# Altium schematic sheet Sheet(sanya).SchDoc
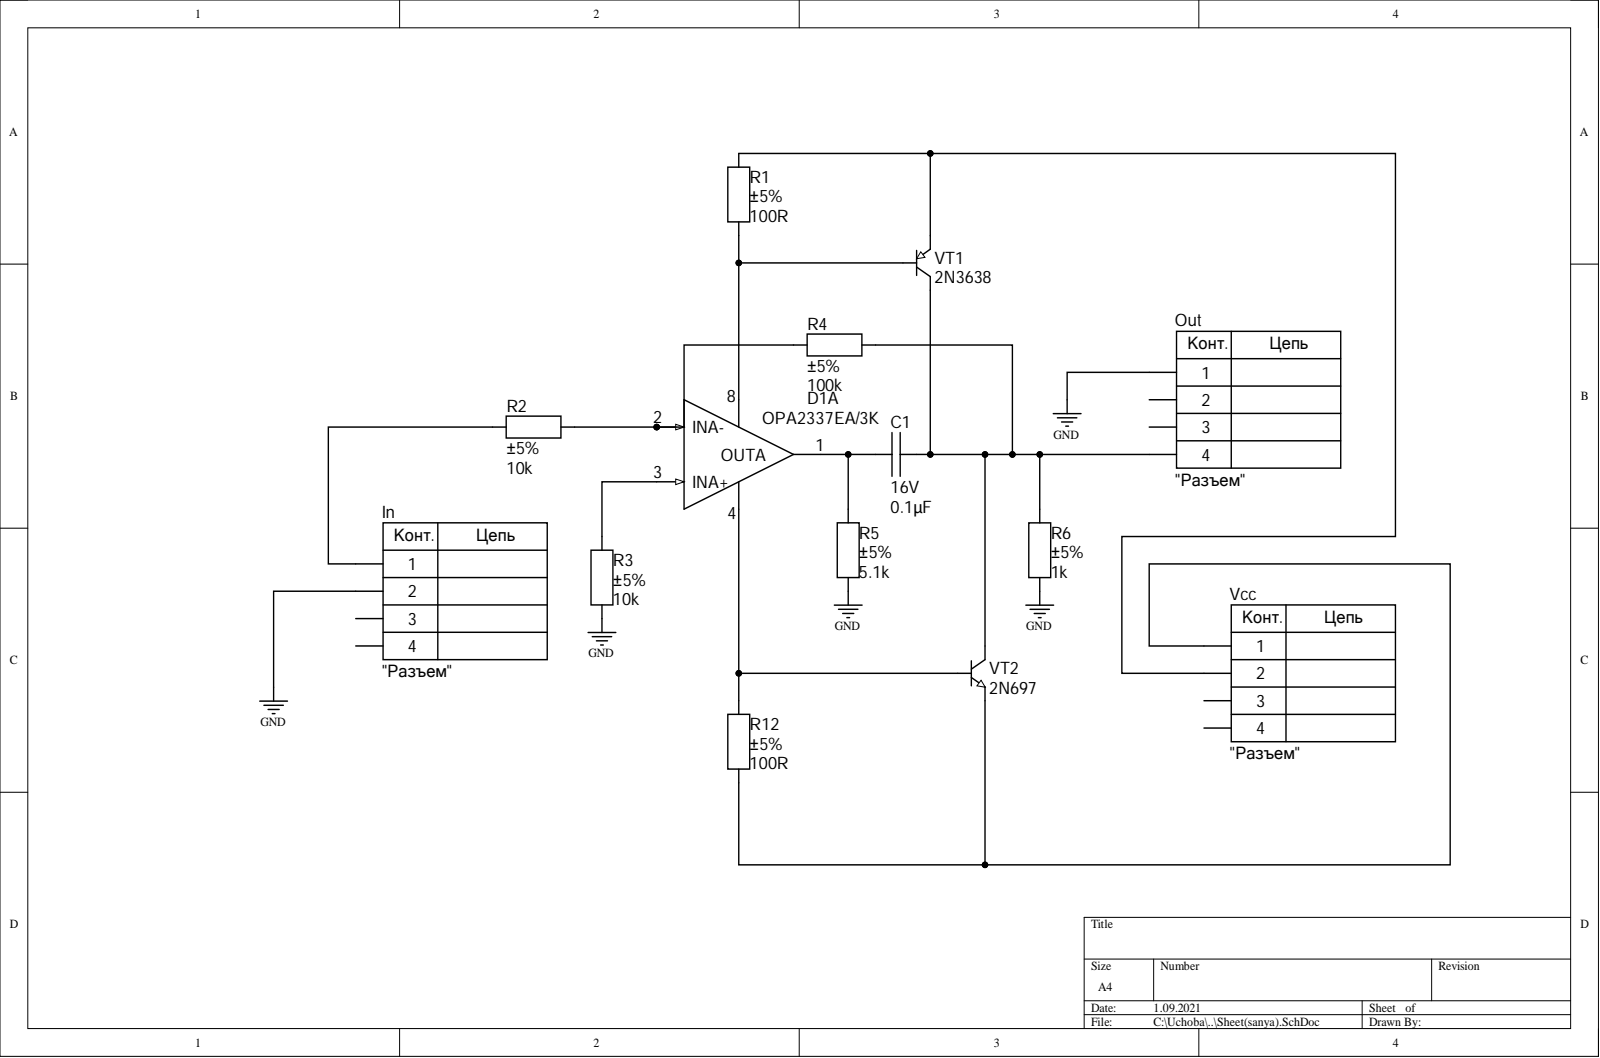
[diagram p0: Sheet(sanya).SchDoc]

## Parts
C1  value 0.1μF  Voltage: 16V  [CAP: 0201]  (hidden comment: Ceramic capacitor, 0.1μF, X7R, ±10%, 16V, 0201, -55...+125°C)
D1  IC op amplifier, Rail-to-Rail, 3 MHz, 2.7...5.5V, -40...+125°C, SOT23-5  [SOT23-8]  (value not drawn)  (part A)
In  CommentText: "Разъем"  [CON: SM04B-GHS]  (hidden comment: Connector, 4pin, 1.25mm, 50VDC, 1A)
Out  CommentText: "Разъем"  [CON: SM04B-GHS]  (hidden comment: Connector, 4pin, 1.25mm, 50VDC, 1A)
R1  value 100R  Tolerance: ±5%  [RES: 0402]  (hidden comment: Resistor film, 100R, ±5%, 0402, 0.063W, -55...+125°C)
R2  value 10k  Tolerance: ±5%  [RES: 0402]  (hidden comment: Resistor film, 10k, ±5%, 0402, 0.063W, -55...+125°C)
R3  value 10k  Tolerance: ±5%  [RES: 0402]  (hidden comment: Resistor film, 10k, ±5%, 0402, 0.063W, -55...+125°C)
R4  value 100k  Tolerance: ±5%  [RES: 0402]  (hidden comment: Resistor film, 100k, ±5%, 0402, 0.063W, -55...+125°C)
R5  value 5.1k  Tolerance: ±5%  [RES: 0402]  (hidden comment: Resistor film, 5.1k, ±5%, 0402, 0.063W, -55...+125°C)
R6  value 1k  Tolerance: ±5%  [RES: 0402]  (hidden comment: Resistor film, 1k, ±5%, 0402, 0.063W, -55...+125°C)
R12  value 100R  Tolerance: ±5%  [RES: 0402]  (hidden comment: Resistor film, 100R, ±5%, 0402, 0.063W, -55...+125°C)
Vcc  CommentText: "Разъем"  [CON: SM04B-GHS]  (hidden comment: Connector, 4pin, 1.25mm, 50VDC, 1A)
VT1  PartNumber: 2N3638  [SOT23]  (hidden comment: PNP transistor, 45V, 100mA, 100MHz, 300mW, 0.65V, 220, SOT-23, -55...+125°C)
VT2  PartNumber: 2N697  [SOT23]  (hidden comment: NPN transistor, 45V, 100mA, 100MHz, 300mW, 0.6V, 420, SOT-23, -55...+125°C)

## Nets
GND: In.2 Out.1 R3.1 R5.2 R6.2
NetC1_1: C1.1 Out.4 R4.1 R6.1 VT1.2 VT2.2
NetC1_2: C1.2 D1.1(OUTA) R5.1
NetD1_2: D1.2(INA-) R2.2 R4.2
NetD1_3: D1.3(INA+) R3.2
NetD1_4: D1.4 R12.1 VT2.1
NetD1_8: D1.8 R1.1 VT1.1
NetIn_1: In.1 R2.1
NetR1_2: R1.2 Vcc.2 VT1.3
NetR12_2: R12.2 Vcc.1 VT2.3

Not connected: In 3 4; Out 2 3; Vcc 3 4

## Library data of the parts
C1 = Ceramic_0201: Manufacturer: Yageo; PartNumber: CC0201KRX7R7BB103; Component Kind: Standard; HelpURL: https://www.digikey.com/product-detail/en/yageo/CC0201KRX7R7BB103/311-1404-1-ND/2833710; Library Name: Capacitor.IntLib; Package: 0201; Temperature: -55...+125°C; Tolerance: ±10%; TypeCapacitor: Ceramic capacitor; TypeDielectric: X7R; ValueManufacturerPartNumber: Ceramic capacitor, 0.1μF, X7R, ±10%, 16V, 0201, -55...+125°C
D1 = OPA2337EA/3K: OP amplifier; Manufacturer: Texas Instruments; GainBandwidth: 3 MHz; Component Kind: Standard; ComponentType: IC op amplifier; HelpURL: https://www.digikey.com/product-detail/en/texas-instruments/OPA2337EA-3K/296-26252-1-ND/2254915; Library Name: IC. Amplifier.IntLib; OutputType: Rail-to-Rail; Package: SOT23-5; PartNumber: OPA2337EA/3K; Supply: 2.7...5.5V; Temperature: -40...+125°C; ValueManufacturerPartNumber: IC op amplifier, Rail-to-Rail, 3 MHz, 2.7...5.5V, -40...+125°C, SOT23-5
In Out Vcc = Connector_SM04B: Manufacturer: JST; PartNumber: SM04B-GHS; Component Kind: Standard; CurrentMax: 1A; HelpURL: https://www.digikey.com/product-detail/en/jst-sales-america-inc/SM04B-GHS-TB-LF-SN/455-1566-1-ND/807834; Library Name: Connector.IntLib; Multichannel: 4pin; Pitch: 1.25mm; TypeConnector: Connector; ValueManufacturerPartNumber: Connector, 4pin, 1.25mm, 50VDC, 1A; VoltageMax: 50VDC
R1-R6 R12 = Resistor_0402: Manufacturer: Yageo; PartNumber: RC0402JR-07100RL; Component Kind: Standard; HelpURL: https://www.digikey.com/product-detail/en/yageo/RC0402JR-07100RL/311-100JRCT-ND/729362; Library Name: Resistor.IntLib; Package: 0402; Power: 0.063W; Temperature: -55...+125°C; TypeResistor: Resistor film
  R1 R12: ValueManufacturerPartNumber: Resistor film, 100R, ±5%, 0402, 0.063W, -55...+125°C
  R2 R3: ValueManufacturerPartNumber: Resistor film, 10k, ±5%, 0402, 0.063W, -55...+125°C
  R4: Value: 100k; ValueManufacturerPartNumber: Resistor film, 100k, ±5%, 0402, 0.063W, -55...+125°C
  R5: ValueManufacturerPartNumber: Resistor film, 5.1k, ±5%, 0402, 0.063W, -55...+125°C
  R6: ValueManufacturerPartNumber: Resistor film, 1k, ±5%, 0402, 0.063W, -55...+125°C
VT1 = BJT_PNP_132: Manufacturer: ON Semiconductor; CurrentGain: 220; Component Kind: Standard; CurrentCE: 100mA; Frequency: 100MHz; HelpURL: https://www.digikey.com/product-detail/en/on-semiconductor/BC857BLT3G/BC857BLT3GOSCT-ND/2704963; Library Name: Transistor.IntLib; MaxPower: 300mW; Package: SOT-23; Temperature: -55...+125°C; TypeTransistor: PNP transistor; ValueManufacturerPartNumber: PNP transistor, 45V, 100mA, 100MHz, 300mW, 0.65V, 220, SOT-23, -55...+125°C; VoltageCE: 45V; VoltageSaturation: 0.65V
VT2 = BJT_NPN_123: Manufacturer: ON Semiconductor; CurrentGain: 420; Component Kind: Standard; CurrentCE: 100mA; Frequency: 100MHz; HelpURL: https://www.digikey.com/product-detail/en/on-semiconductor/BC847CLT1G/BC847CLT1GOSCT-ND/917836; Library Name: Transistor.IntLib; MaxPower: 300mW; Package: SOT-23; Temperature: -55...+125°C; TypeTransistor: NPN transistor; ValueManufacturerPartNumber: NPN transistor, 45V, 100mA, 100MHz, 300mW, 0.6V, 420, SOT-23, -55...+125°C; VoltageCE: 45V; VoltageSaturation: 0.6V
